# Altium schematic sheet Application_MCU.SchDoc(Application_MCU)
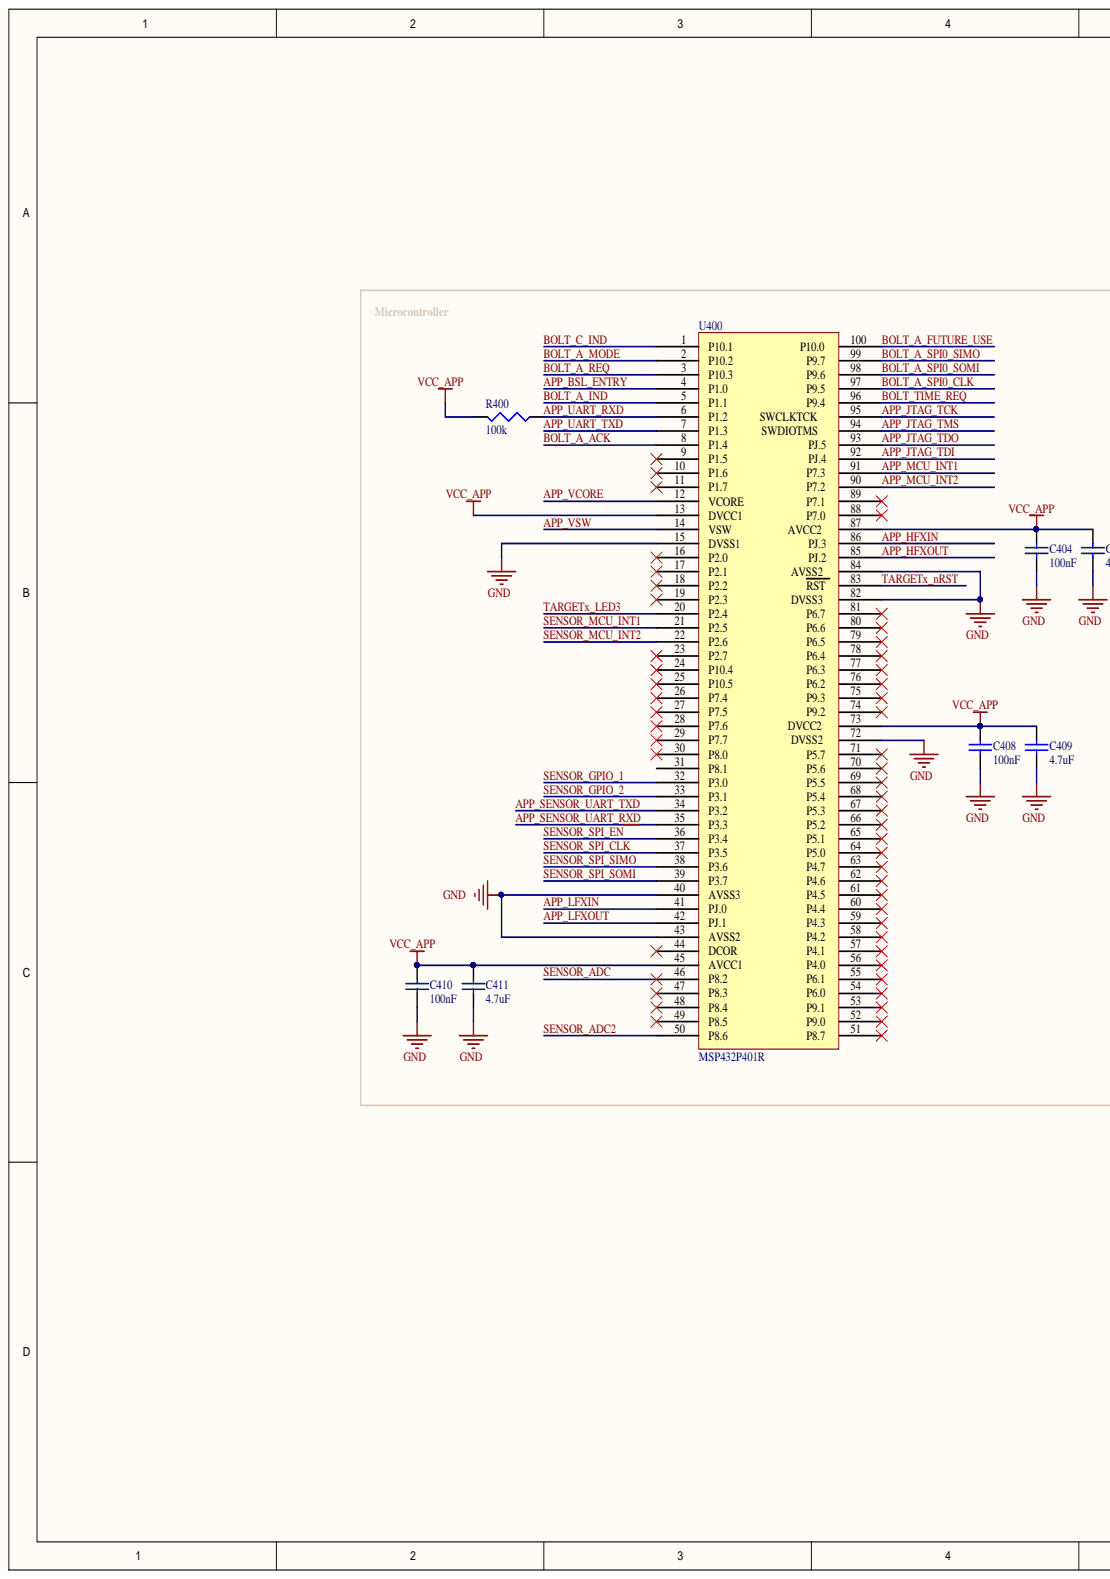
[diagram p0: Application_MCU.SchDoc, left]
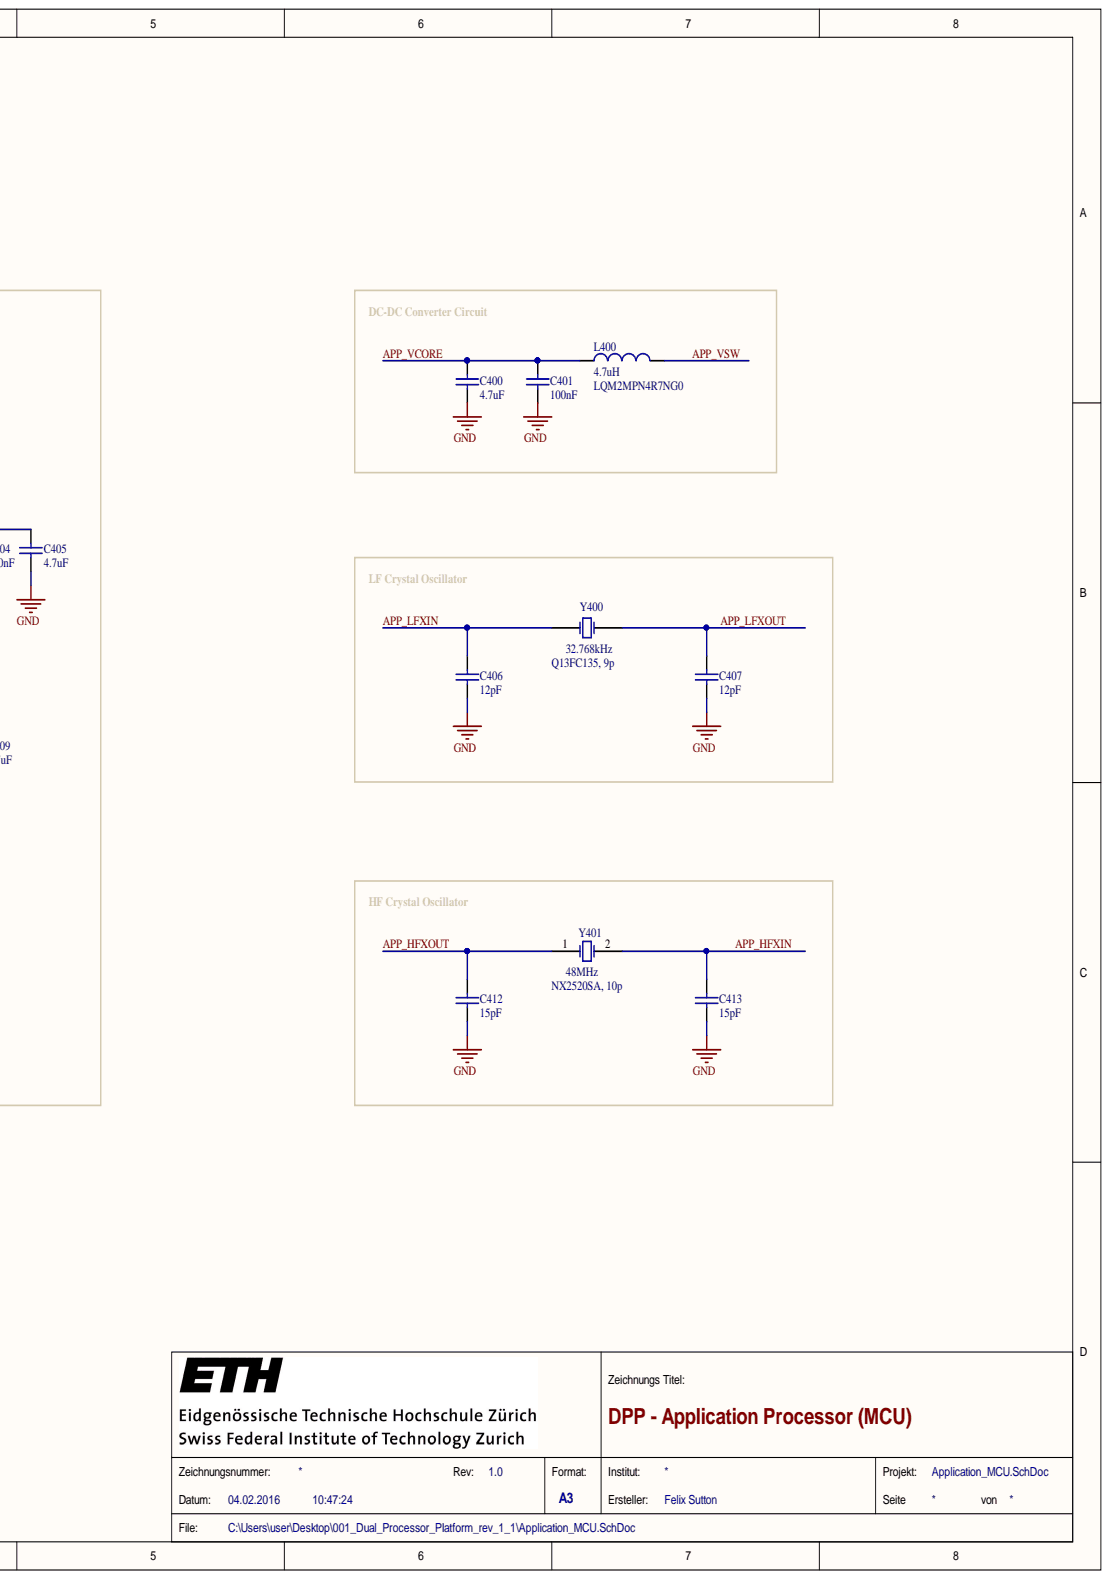
[diagram p1: Application_MCU.SchDoc, right]

## Parts
C400  4.7uF  [CAPC1005N]
C401  100nF  [CAPC1005N]
C404  100nF  [CAPC1005N]
C405  4.7uF  [CAPC1005N]
C406  12pF  [CAPC1005N]
C407  12pF  [CAPC1005N]
C408  100nF  [CAPC1005N]
C409  4.7uF  [CAPC1005N]
C410  100nF  [CAPC1005N]
C411  4.7uF  [CAPC1005N]
C412  15pF  [CAPC1005N]
C413  15pF  [CAPC1005N]
L400  4.7uH  value LQM2MPN4R7NG0  [INDC2012M]
R400  100k  [RESC1005N]
U400  MSP432P401R  [PQFP_100]
Y400  32.768kHz  [FC_135]
Y401  48MHz  [NX2520SA]

## Nets
GND: C400.1 C401.1 C404.2 C405.2 C406.2 C407.2 C408.2 C409.2 C410.2 C411.2 C412.2 C413.2 U400.15(DVSS1) U400.40(AVSS3) U400.43(AVSS2) U400.72(DVSS2) U400.82(DVSS3) U400.84(AVSS2)
VCC_APP: C404.1 C405.1 C408.1 C409.1 C410.1 C411.1 R400.2 U400.13(DVCC1) U400.45(AVCC1) U400.73(DVCC2) U400.87(AVCC2)
APP_BSL_ENTRY: U400.4(P1.0)
APP_HFXIN: C413.1 U400.86(PJ.3) Y401.2
APP_HFXOUT: C412.1 U400.85(PJ.2) Y401.1
APP_JTAG_TCK: U400.95(SWCLKTCK)
APP_JTAG_TDI: U400.92(PJ.4)
APP_JTAG_TDO: U400.93(PJ.5)
APP_JTAG_TMS: U400.94(SWDIOTMS)
APP_LFXIN: C406.1 U400.41(PJ.0) Y400.1
APP_LFXOUT: C407.1 U400.42(PJ.1) Y400.2
APP_MCU_INT1: U400.91(P7.3)
APP_MCU_INT2: U400.90(P7.2)
APP_SENSOR_UART_RXD: U400.35(P3.3)
APP_SENSOR_UART_TXD: U400.34(P3.2)
APP_UART_RXD: R400.1 U400.6(P1.2)
APP_UART_TXD: U400.7(P1.3)
APP_VCORE: C400.2 C401.2 L400.1 U400.12(VCORE)
APP_VSW: L400.2 U400.14(VSW)
BOLT_A_ACK: U400.8(P1.4)
BOLT_A_FUTURE_USE: U400.100(P10.0)
BOLT_A_IND: U400.5(P1.1)
BOLT_A_MODE: U400.2(P10.2)
BOLT_A_REQ: U400.3(P10.3)
BOLT_A_SPI0_CLK: U400.97(P9.5)
BOLT_A_SPI0_SIMO: U400.99(P9.7)
BOLT_A_SPI0_SOMI: U400.98(P9.6)
BOLT_C_IND: U400.1(P10.1)
BOLT_TIME_REQ: U400.96(P9.4)
SENSOR_ADC: U400.46(P8.2)
SENSOR_ADC2: U400.50(P8.6)
SENSOR_GPIO_1: U400.32(P3.0)
SENSOR_GPIO_2: U400.33(P3.1)
SENSOR_MCU_INT1: U400.21(P2.5)
SENSOR_MCU_INT2: U400.22(P2.6)
SENSOR_SPI_CLK: U400.37(P3.5)
SENSOR_SPI_~{EN}: U400.36(P3.4)
SENSOR_SPI_SIMO: U400.38(P3.6)
SENSOR_SPI_SOMI: U400.39(P3.7)
TARGETX_LED3: U400.20(P2.4)
TARGETX_NRST: U400.83(RST)

Not connected: U400 9(P1.5) 10(P1.6) 11(P1.7) 16(P2.0) 17(P2.1) 18(P2.2) 19(P2.3) 23(P2.7) 24(P10.4) 25(P10.5) 26(P7.4) 27(P7.5) 28(P7.6) 29(P7.7) 30(P8.0) 31(P8.1) 44(DCOR) 47(P8.3) 48(P8.4) 49(P8.5) 51(P8.7) 52(P9.0) 53(P9.1) 54(P6.0) 55(P6.1) 56(P4.0) 57(P4.1) 58(P4.2) 59(P4.3) 60(P4.4) 61(P4.5) 62(P4.6) 63(P4.7) 64(P5.0) 65(P5.1) 66(P5.2) 67(P5.3) 68(P5.4) 69(P5.5) 70(P5.6) 71(P5.7) 74(P9.2) 75(P9.3) 76(P6.2) 77(P6.3) 78(P6.4) 79(P6.5) 80(P6.6) 81(P6.7) 88(P7.0) 89(P7.1)

## Library data of the parts
C400 C401 C404-C413 = Cap: Capacitor; Component Kind: Standard; LatestRevisionDate: 17-Jul-2002; LatestRevisionNote: Re-released for DXP Platform.; Library Name: Miscellaneous Devices.IntLib; PackageReference: RAD-0.3; Published: 8-Jun-2000; Publisher: Altium Limited
L400 = Inductor: Code_IEC: 1005; Code_JEITA: 0402; Component Kind: Standard; Description: Inductor; LatestRevisionDate: 29-May-2009; LatestRevisionNote: IPC-7351 Footprint Added.; Library Name: Miscellaneous Devices.IntLib; PackageDescription: Chip Inductor; PackageReference: 0402-A; Published: 8-Jun-2000; Signal Integrity: Inductor; Simulation: INDUCTOR; Publisher: Altium Limited
R400 = Res3: Resistor; Component Kind: Standard; LatestRevisionDate: 29-May-2009; LatestRevisionNote: IPC-7351 Footprint Added.; Library Name: Miscellaneous Devices.IntLib; PackageDescription: Chip Resistor; PackageReference: J1-0603; Published: 8-Jun-2000; Publisher: Altium Limited
U400 = MSP432P401R: TI MSP432P401 MCU; Component Kind: Standard; Library Name: Felix_Component_Library.SchLib
Y400 = FC-135: Crystal Oscillator, 32.768kHz, 12.5pF; Component Kind: Standard; Supplier 1: Digi-Key; Supplier 2: Digi-Key; Supplier 3: Farnell; Supplier Part Number 1: SE2413DKR-ND; Supplier Part Number 2: SE2413CT-ND; Supplier Part Number 3: 1278036; Temperature: -40 +85; Library Name: PermaSense.SchLib
Y401 = XTAL: Crystal Oscillator; Component Kind: Standard; LatestRevisionDate: 17-Jul-2002; LatestRevisionNote: Re-released for DXP Platform.; Library Name: Miscellaneous Devices.IntLib; PackageReference: R38; Published: 8-Jun-2000; Publisher: Altium Limited
